# Altium schematic sheet Sch7 - Switch Ethernet.SchDoc("Sch7 - Switch Ethernet")
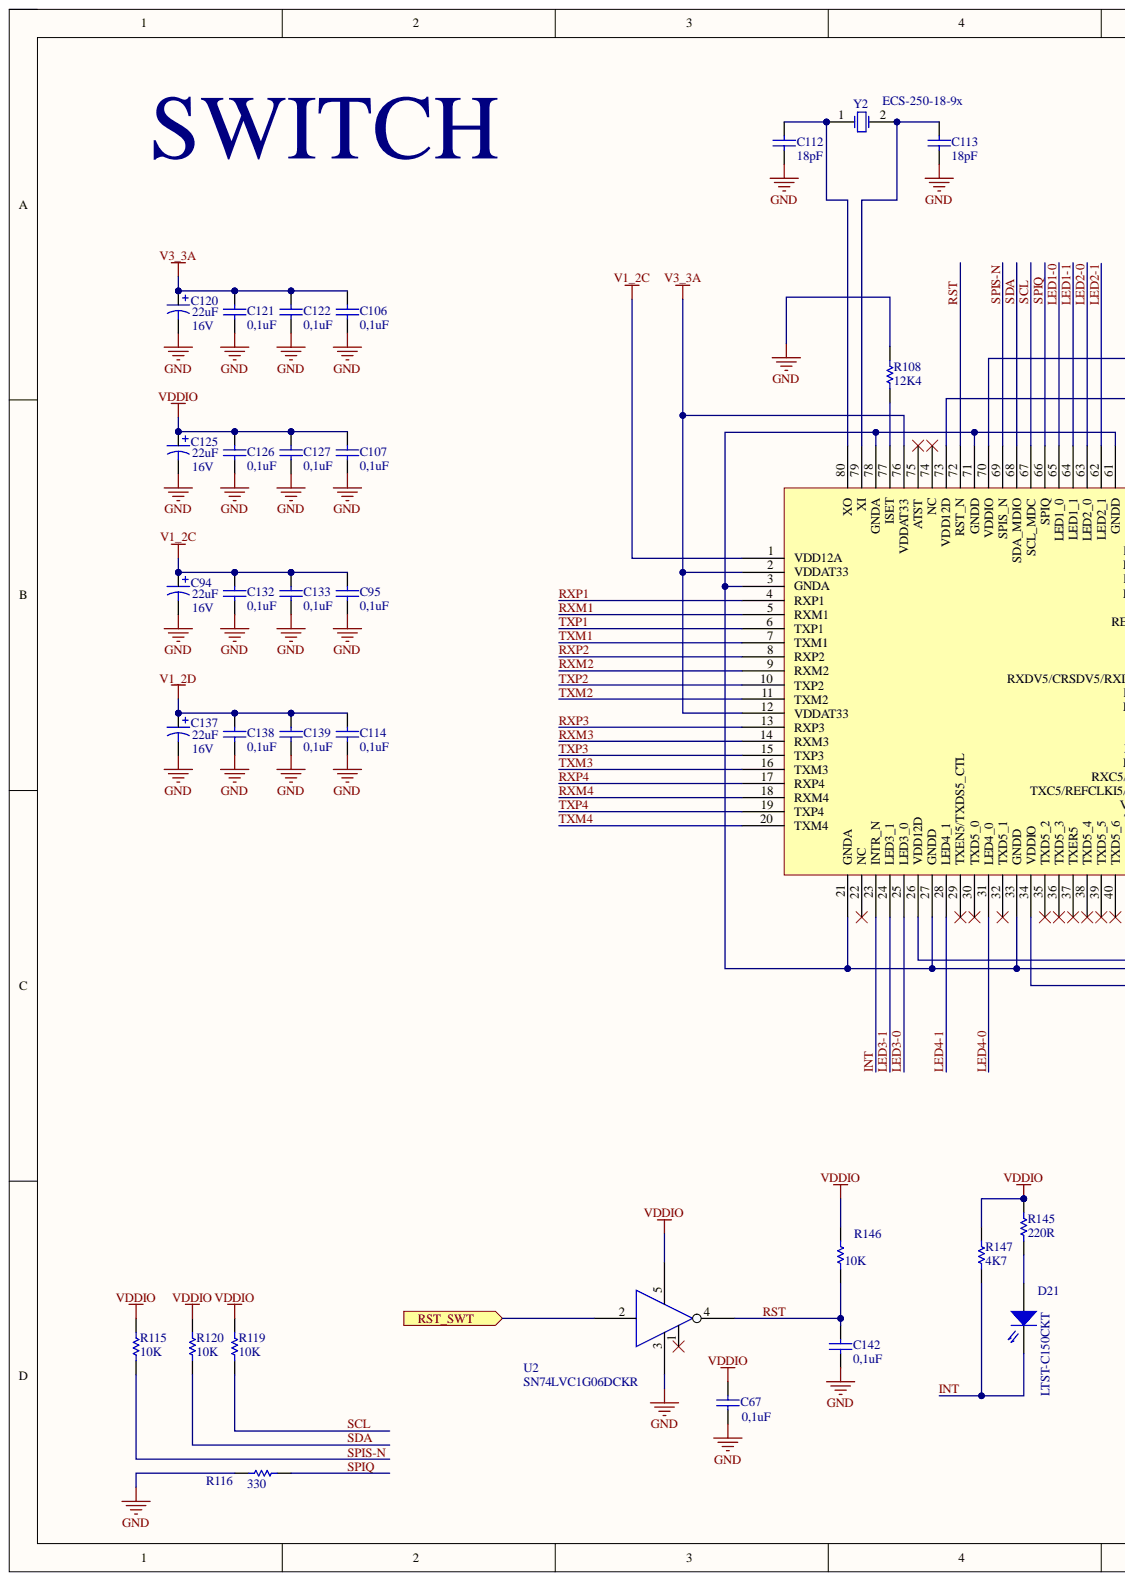
[diagram p0: Sch7 - Switch Ethernet.SchDoc, left]
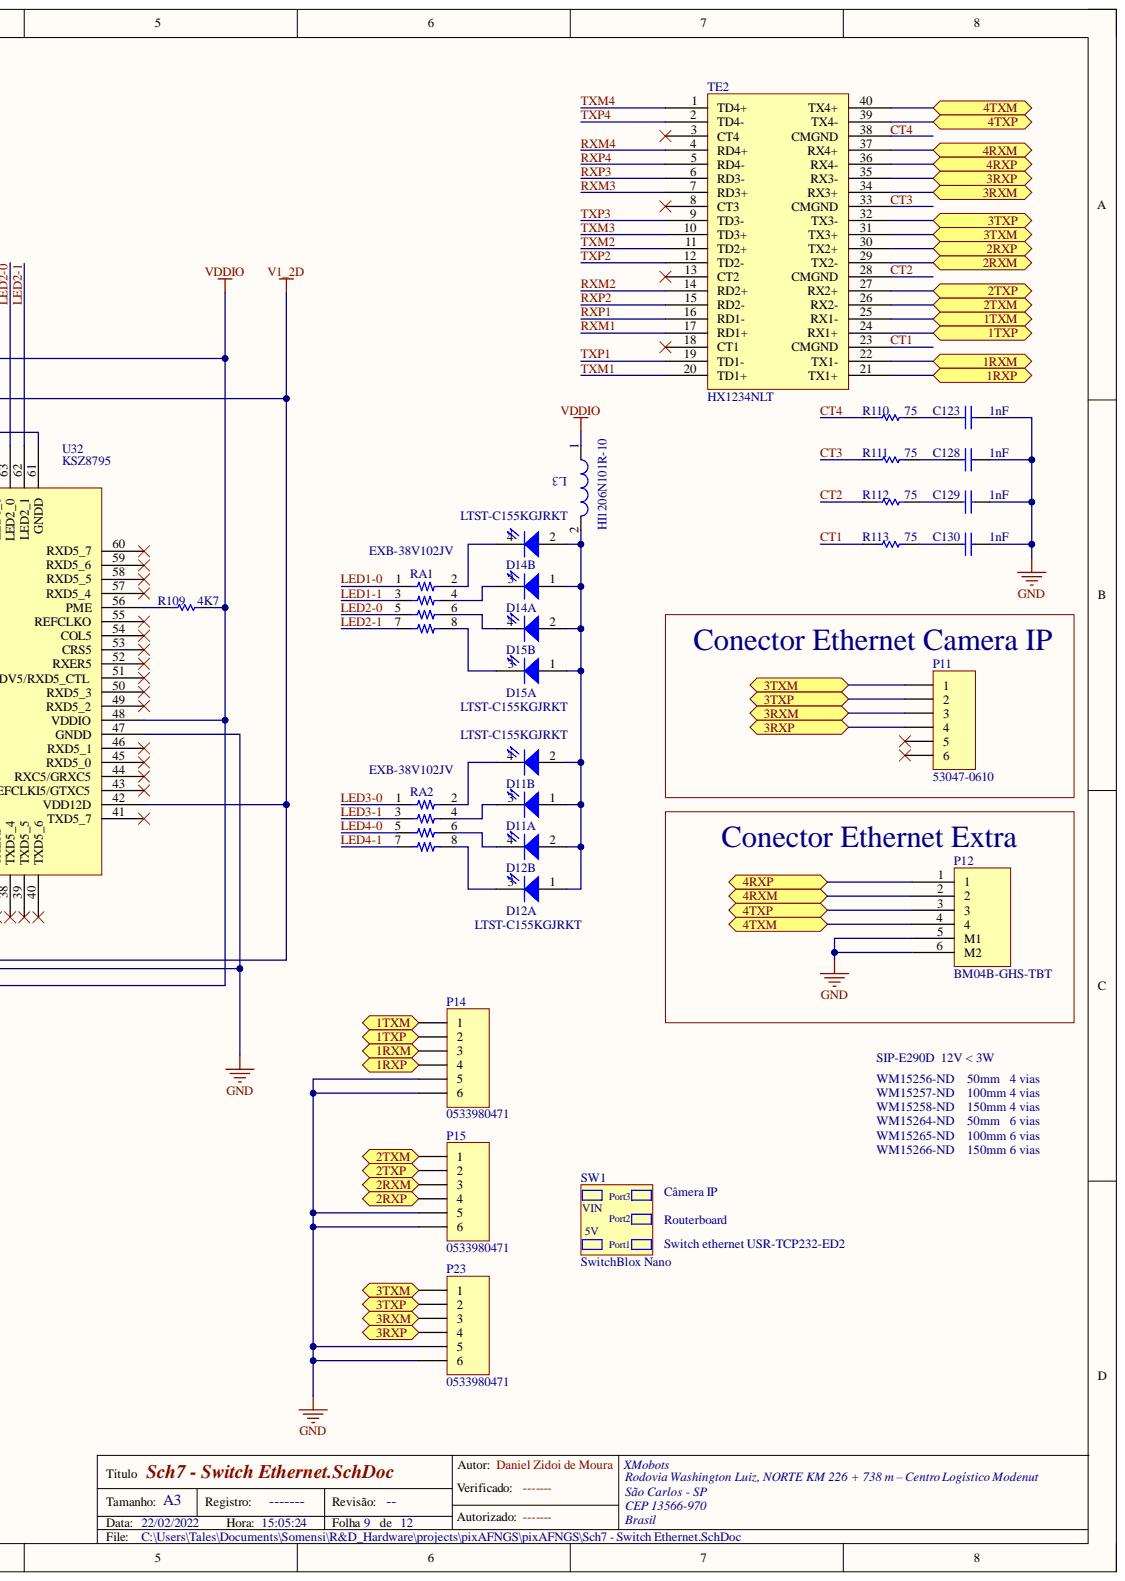
[diagram p1: Sch7 - Switch Ethernet.SchDoc, right]

## Parts
C67  value 0,1uF  [0603]  (hidden comment: Cap Semi)
C94  value 22uF  Voltage: 16V  [C]  (hidden comment: T495C107K006ATE150)
C95  value 0,1uF  [0603]  (hidden comment: Cap Semi)
C106  value 0,1uF  [0603]  (hidden comment: Cap Semi)
C107  value 0,1uF  [0603]  (hidden comment: Cap Semi)
C112  value 18pF  [0603]  (hidden comment: Cap Semi)
C113  value 18pF  [0603]  (hidden comment: Cap Semi)
C114  value 0,1uF  [0603]  (hidden comment: Cap Semi)
C120  value 22uF  Voltage: 16V  [C]  (hidden comment: T495C107K006ATE150)
C121  value 0,1uF  [0603]  (hidden comment: Cap Semi)
C122  value 0,1uF  [0603]  (hidden comment: Cap Semi)
C123  value 1nF  [0603]  (hidden comment: Cap Semi)
C125  value 22uF  Voltage: 16V  [C]  (hidden comment: T495C107K006ATE150)
C126  value 0,1uF  [0603]  (hidden comment: Cap Semi)
C127  value 0,1uF  [0603]  (hidden comment: Cap Semi)
C128  value 1nF  [0603]  (hidden comment: Cap Semi)
C129  value 1nF  [0603]  (hidden comment: Cap Semi)
C130  value 1nF  [0603]  (hidden comment: Cap Semi)
C132  value 0,1uF  [0603]  (hidden comment: Cap Semi)
C133  value 0,1uF  [0603]  (hidden comment: Cap Semi)
C137  value 22uF  Voltage: 16V  [C]  (hidden comment: T495C107K006ATE150)
C138  value 0,1uF  [0603]  (hidden comment: Cap Semi)
C139  value 0,1uF  [0603]  (hidden comment: Cap Semi)
C142  value 0,1uF  [0603]  (hidden comment: Cap Semi)
D11  LTST-C155KGJRKT  [LTST-C155KGJSKT]  (parts A,B)
D12  LTST-C155KGJRKT  [LTST-C155KGJSKT]  (parts A,B)
D14  LTST-C155KGJRKT  [LTST-C155KGJSKT]  (parts A,B)
D15  LTST-C155KGJRKT  [LTST-C155KGJSKT]  (parts A,B)
D21  LTST-C150CKT  [LED 1206]
L3  HI1206N101R-10  [1206]
P11  53047-0610  [53047-0610]
P12  BM04B-GHS-TBT  [BM04B-GHS-TBT]
P14  0533980471  [53398-0471  Molex]
P15  0533980471  [53398-0471  Molex]
P23  0533980471  [53398-0471  Molex]
R108  value 12K4  [Res_0603]  (hidden comment: Res Semi)
R109  value 4K7  [Res_0603]  (hidden comment: Res Semi)
R110  value 75  [Res_0603]  (hidden comment: Res Semi)
R111  value 75  [Res_0603]  (hidden comment: Res Semi)
R112  value 75  [Res_0603]  (hidden comment: Res Semi)
R113  value 75  [Res_0603]  (hidden comment: Res Semi)
R115  value 10K  [Res_0603]  (hidden comment: Res Semi)
R116  value 330  [Res_0603]  (hidden comment: Res Semi)
R119  value 10K  [Res_0603]  (hidden comment: Res Semi)
R120  value 10K  [Res_0603]  (hidden comment: Res Semi)
R145  value 220R  [Res_0603]  (hidden comment: Res Semi)
R146  value 10K  [Res_0603]  (hidden comment: Res Semi)
R147  value 4K7  [Res_0603]  (hidden comment: Res Semi)
RA1  EXB38V  Supplier Part Number 1: EXB-38V102JV  [1206_4]
RA2  EXB38V  Supplier Part Number 1: EXB-38V102JV  [1206_4]
SW1  SwitchBlox Nano  [SwitchBlox Nano]
TE2  HX1234NLT  [HX1234NLT]
U2  SN74LVC1G06DCKR  [SOT23-5_DBV_PDSO_G5]
U32  KSZ8795  [LQFP 80]
Y2  value ECS-250-18-9x  [BCY-W2/D3.1]  (hidden comment: XTAL)

## Ports
1RXM, 1RXP, 1TXM, 1TXP, 2RXM, 2RXP, 2TXM, 2TXP, 3RXM, 3RXP, 3TXM, 3TXP, 4RXM, 4RXP, 4TXM, 4TXP, RST_SWT

## Nets
GND: C67.2 C94.2 C95.1 C106.1 C107.1 C112.1 C113.1 C114.1 C120.2 C121.1 C122.1 C123.1 C125.2 C126.1 C127.1 C128.1 C129.1 C130.1 C132.1 C133.1 C137.2 C138.1 C139.1 C142.1 P12.5(M1) P12.6(M2) P14.5 P14.6 P15.5 P15.6 P23.5 P23.6 R108.1 R116.2 U2.3 U32.3(GNDA) U32.21(GNDA) U32.27(GNDD) U32.33(GNDD) U32.47(GNDD) U32.61(GNDD) U32.71(GNDD) U32.78(GNDA)
V1_2C: C94.1 C95.2 C132.2 C133.2 U32.1(VDD12A)
V1_2D: C114.2 C137.1 C138.2 C139.2 U32.26(VDD12D) U32.42(VDD12D) U32.73(VDD12D)
V3_3A: C106.2 C120.1 C121.2 C122.2 U32.2(VDDAT33) U32.12(VDDAT33) U32.76(VDDAT33)
VDDIO: C67.1 C107.2 C125.1 C126.2 C127.2 L3.1 R109.2 R115.2 R119.2 R120.2 R145.1 R146.2 R147.2 U2.5 U32.34(VDDIO) U32.48(VDDIO) U32.70(VDDIO)
1RXM: P14.3 TE2.22(TX1-) ; port 1RXM
1RXP: P14.4 TE2.21(TX1+) ; port 1RXP
1TXM: P14.1 TE2.25(RX1-) ; port 1TXM
1TXP: P14.2 TE2.24(RX1+) ; port 1TXP
2RXM: P15.3 TE2.29(TX2-) ; port 2RXM
2RXP: P15.4 TE2.30(TX2+) ; port 2RXP
2TXM: P15.1 TE2.26(RX2-) ; port 2TXM
2TXP: P15.2 TE2.27(RX2+) ; port 2TXP
3RXM: P11.3 P23.3 TE2.34(RX3+) ; port 3RXM
3RXP: P11.4 P23.4 TE2.35(RX3-) ; port 3RXP
3TXM: P11.1 P23.1 TE2.31(TX3+) ; port 3TXM
3TXP: P11.2 P23.2 TE2.32(TX3-) ; port 3TXP
4RXM: P12.2 TE2.37(RX4+) ; port 4RXM
4RXP: P12.1 TE2.36(RX4-) ; port 4RXP
4TXM: P12.4 TE2.40(TX4+) ; port 4TXM
4TXP: P12.3 TE2.39(TX4-) ; port 4TXP
CT1: R113.1 TE2.23(CMGND)
CT2: R112.1 TE2.28(CMGND)
CT3: R111.1 TE2.33(CMGND)
CT4: R110.1 TE2.38(CMGND)
INT: D21.2 R147.1 U32.23(INTR_N)
LED1-0: RA1.1 U32.65(LED1_0)
LED1-1: RA1.3 U32.64(LED1_1)
LED2-0: RA1.5 U32.63(LED2_0)
LED2-1: RA1.7 U32.62(LED2_1)
LED3-0: RA2.1 U32.25(LED3_0)
LED3-1: RA2.3 U32.24(LED3_1)
LED4-0: RA2.5 U32.31(LED4_0)
LED4-1: RA2.7 U32.28(LED4_1)
RST: C142.2 R146.1 U2.4 U32.72(RST_N)
RST_SWT: U2.2 ; port RST_SWT
RXM1: TE2.17(RD1+) U32.5(RXM1)
RXM2: TE2.14(RD2+) U32.9(RXM2)
RXM3: TE2.7(RD3+) U32.14(RXM3)
RXM4: TE2.4(RD4+) U32.18(RXM4)
RXP1: TE2.16(RD1-) U32.4(RXP1)
RXP2: TE2.15(RD2-) U32.8(RXP2)
RXP3: TE2.6(RD3-) U32.13(RXP3)
RXP4: TE2.5(RD4-) U32.17(RXP4)
SCL: R119.1 U32.67(SCL_MDC)
SDA: R120.1 U32.68(SDA_MDIO)
SPIQ: R116.1 U32.66(SPIQ)
SPIS-N: R115.1 U32.69(SPIS_N)
TXM1: TE2.20(TD1+) U32.7(TXM1)
TXM2: TE2.11(TD2+) U32.11(TXM2)
TXM3: TE2.10(TD3+) U32.16(TXM3)
TXM4: TE2.1(TD4+) U32.20(TXM4)
TXP1: TE2.19(TD1-) U32.6(TXP1)
TXP2: TE2.12(TD2-) U32.10(TXP2)
TXP3: TE2.9(TD3-) U32.15(TXP3)
TXP4: TE2.2(TD4-) U32.19(TXP4)
NetC112_2: C112.2 U32.80(XO) Y2.1
NetC113_2: C113.2 U32.79(XI) Y2.2
NetC123_2: C123.2 R110.2
NetC128_2: C128.2 R111.2
NetC129_2: C129.2 R112.2
NetC130_2: C130.2 R113.2
NetD11_1: D11.1 D11.2 D12.1 D12.2 D14.1 D14.2 D15.1 D15.2 L3.2
NetD11_3: D11.3 RA2.4
NetD11_4: D11.4 RA2.2
NetD12_3: D12.3 RA2.8
NetD12_4: D12.4 RA2.6
NetD14_3: D14.3 RA1.4
NetD14_4: D14.4 RA1.2
NetD15_3: D15.3 RA1.8
NetD15_4: D15.4 RA1.6
NetD21_1: D21.1 R145.2
NetR108_2: R108.2 U32.77(ISET)
NetR109_1: R109.1 U32.56(PME)

Not connected: P11 5 6; TE2 3(CT4) 8(CT3) 13(CT2) 18(CT1); U2 1; U32 22(NC) 29(TXEN5/TXDS5_CTL) 30(TXD5_0) 32(TXD5_1) 35(TXD5_2) 36(TXD5_3) 37(TXER5) 38(TXD5_4) 39(TXD5_5) 40(TXD5_6) 41(TXD5_7) 43(TXC5/REFCLKI5/GTXC5) 44(RXC5/GRXC5) 45(RXD5_0) 46(RXD5_1) 49(RXD5_2) 50(RXD5_3) 51(RXDV5/CRSDV5/RXD5_CTL) 52(RXER5) 53(CRS5) 54(COL5) 55(REFCLKO) 57(RXD5_4) 58(RXD5_5) 59(RXD5_6) 60(RXD5_7) 74(NC) 75(ATST)

## Library data of the parts
C67 C95 C106 C107 C112-C114 C121-C123 C126-C130 C132 C133 C138 C139 C142 = Cap Semi: Capacitor (Semiconductor SIM Model); Component Kind: Standard; Library Name: Miscellaneous Devices.IntLib; PackageReference: C1206; Published: 8-Jun-2000; Signal Integrity: Cap Semi; Simulation: CAP; Publisher: Altium Limited
  C67: LatestRevisionDate: 29-May-2009; LatestRevisionNote: IPC-7351 Footprint Added.; PackageDescription: Chip Capacitor
  C95 C106 C107 C112-C114 C121-C123 C126-C130 C132 C133 C138 C139 C142: LatestRevisionDate: 17-Jul-2002; LatestRevisionNote: Re-released for DXP Platform.
C94 C120 C125 C137 = Cap Pol3: Polarized Capacitor (Surface Mount); Component Kind: Standard; LatestRevisionDate: 29-May-2009; LatestRevisionNote: IPC-7351 Footprint Added.; Library Name: Miscellaneous Devices.IntLib; PackageDescription: Chip Capacitor; PackageReference: C0805; Published: 8-Jun-2000; Signal Integrity: Cap Pol; Simulation: CAP; Publisher: Altium Limited
D21 = LTST-C150CKT: LED RED CLEAR 1206 SMD; Component Kind: Standard; Supplier 1: Digi-Key; Supplier Part Number 1: 160-1167-1-ND; Library Name: XM_Diodes.SchLib
L3 = BLM18SG221TN1D: FERRITE CHIP 220 OHM 2500MA 0603; Component Kind: Standard; Supplier 1: Digi-Key; Supplier Part Number 1: 490-5225-1-ND; Library Name: XM_Inductor.SchLib
P11 = Header 6: Header, 6-Pin; Component Kind: Standard; LatestRevisionDate: 17-Jul-2002; LatestRevisionNote: Re-released for DXP Platform.; Library Name: Miscellaneous Connectors.IntLib; Signal Integrity: Connector; Publisher: Altium Limited
P12 = BM04B-GHS-TBT: JST GH 4 Vias Vertical; Component Kind: Standard; Library Name: XM_Connector.SchLib
P14 P15 P23 = Header 6H: Header, 6-Pin, Right Angle; Component Kind: Standard; LatestRevisionDate: 17-Jul-2002; LatestRevisionNote: Re-released for DXP Platform.; Library Name: Miscellaneous Connectors.IntLib; Signal Integrity: Connector; Publisher: Altium Limited
R108-R113 R115 R116 R119 R120 R145-R147 = Res Semi: Semiconductor Resistor; Component Kind: Standard; LatestRevisionDate: 17-Jul-2002; LatestRevisionNote: Re-released for DXP Platform.; Library Name: Miscellaneous Devices.IntLib; PackageReference: AXIAL-0.5; Published: 8-Jun-2000; Signal Integrity: Res Semi; Simulation: RES; Publisher: Altium Limited
RA1 RA2 = EXB38V: RES ARRAY 4 RES 0603; Component Kind: Standard; Supplier 1: Digi-Key; Library Name: XM_Silicon.SchLib
SW1 = SwitchBlox Nano: Switche ethernet 3 portas; Component Kind: Standard; Library Name: XM_Silicon.SchLib
TE2 = HX1234NLT: XFRMR MODUL AUTO MDI/MDIX 10/100 4 PORT; Component Kind: Standard; Library Name: XM_Silicon.SchLib
U2 = SN74LVC1G06DCKR: SINGLEINVERTERBUFFER/DRIVER  WITHOPEN-DRAINOUTPUT; Component Kind: Standard; Supplier 1: Digi-Key; Supplier Part Number 1: 296-8484-1-ND; Library Name: XM_IC.SchLib
Y2 = XTAL: Crystal Oscillator; Component Kind: Standard; LatestRevisionDate: 17-Jul-2002; LatestRevisionNote: Re-released for DXP Platform.; Library Name: Miscellaneous Devices.IntLib; PackageReference: R38; Published: 8-Jun-2000; Simulation: XTAL; Publisher: Altium Limited
D11 D12 D14 D15 = LTST-C155KGJRKT: Component Kind: Standard; Library Name: XM_Diodes.SchLib
U32 = KSZ8795: Component Kind: Standard; Library Name: XM_IC.SchLib
